# Altium schematic sheet ESP32.SchDoc(ESP32)
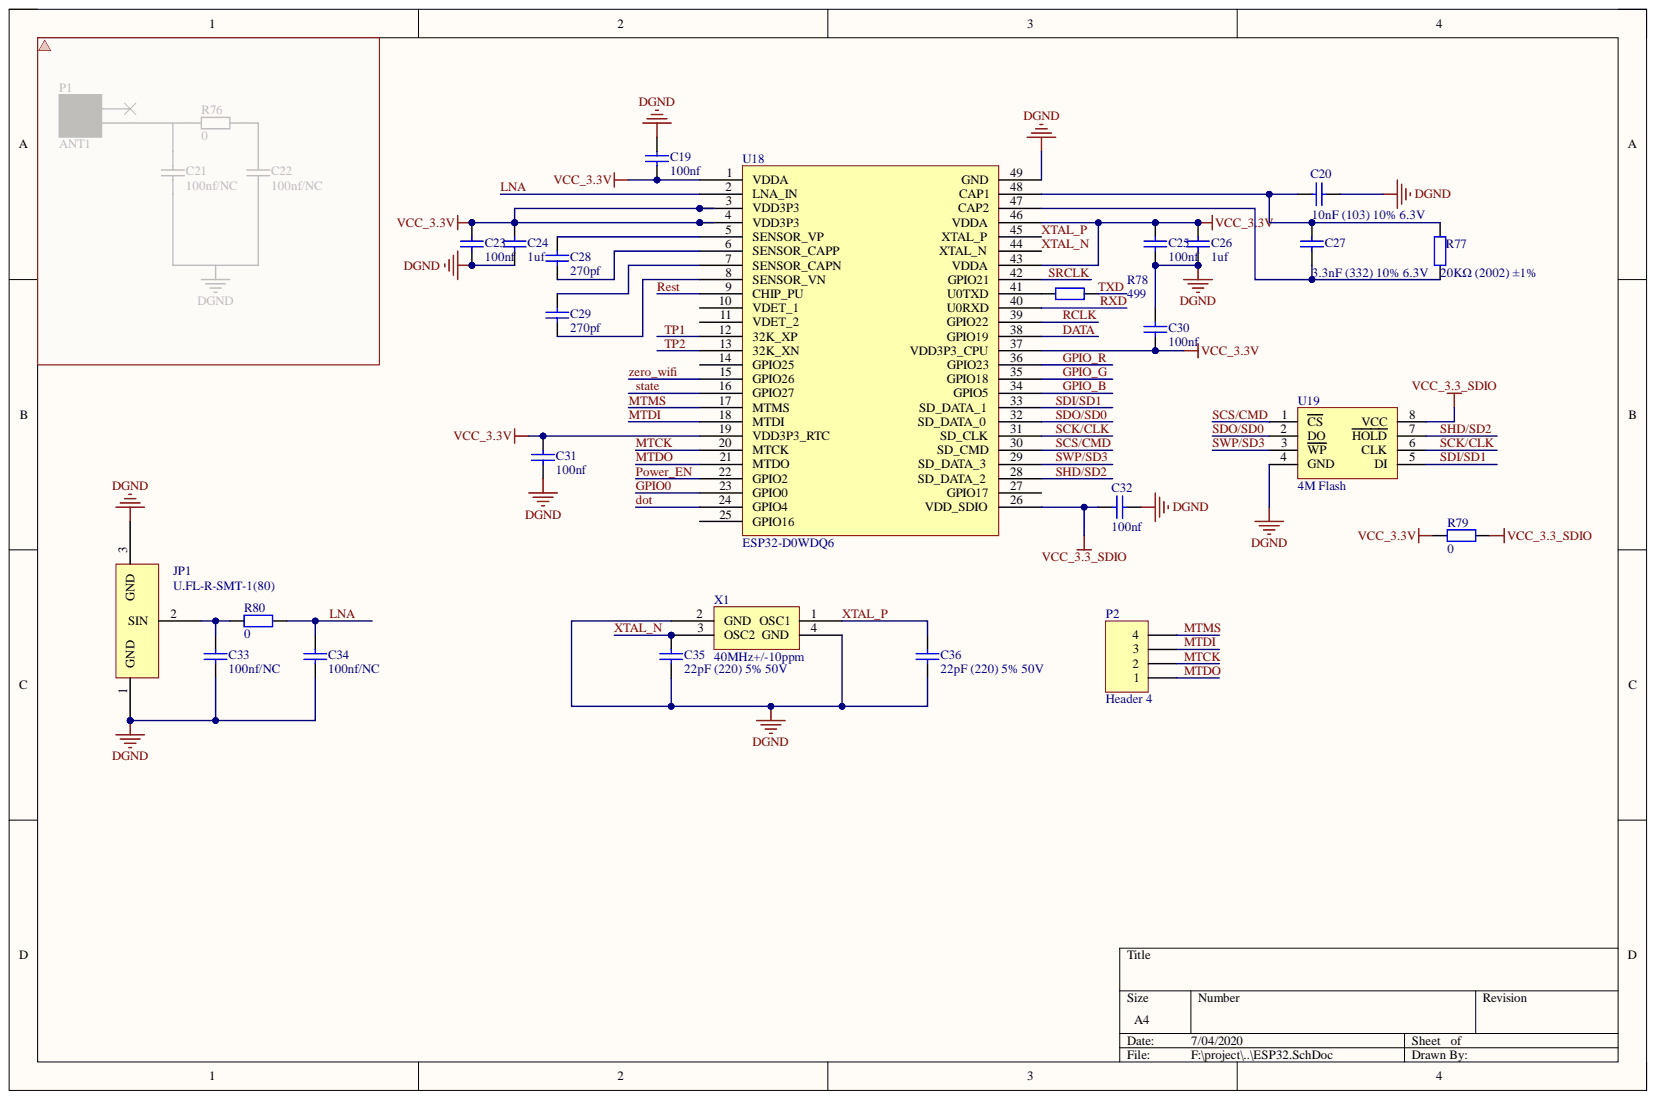
[diagram p0: ESP32.SchDoc]

## Parts
C19  100nf  [0603_C]
C20  10nF (103) 10% 6.3V  [0603_C]
C23  100nf  [0603_C]
C24  1uf  [0603_C]
C25  100nf  [0603_C]
C26  1uf  [0603_C]
C27  3.3nF (332) 10% 6.3V  [0603_C]
C28  270pf  [0603_C]
C29  270pf  [0603_C]
C30  100nf  [0603_C]
C31  100nf  [0603_C]
C32  100nf  [0603_C]
C33  100nf/NC  [0603_C]
C34  100nf/NC  [0603_C]
C35  22pF (220) 5% 50V  [0603_C]
C36  22pF (220) 5% 50V  [0603_C]
JP1  U.FL-R-SMT-1(80)  [RF-SMD_U.FL-R-SMT-1(80)]
P2  Header 4  [HDR1X4]
R77  20KΩ (2002) ±1%  [0603_R]
R78  499  [0603_R]
R79  0  [0603_R]
R80  0  [0603_R]
U18  ESP32-D0WDQ6  [QFN-48_L6.0-W6.0-P0.40-BL-EP3.8]
U19  4M Flash  [SOIC-8_208mil]
X1  40MHz+/-10ppm  [SMD-3225_4P]

## Nets
DGND: C19.2 C20.2 C23.2 C24.2 C25.2 C26.2 C30.1 C31.2 C32.1 C33.2 C34.2 C35.1 C36.1 JP1.1 JP1.3 U18.49(GND) U19.4(GND) X1.2(GND) X1.4(GND)
VCC_3.3_SDIO: C32.2 R79.1 U18.26(VDD_SDIO) U19.8(VCC)
VCC_3.3V: C19.1 C23.1 C24.1 C25.1 C26.1 C30.2 C31.1 R79.2 U18.1(VDDA) U18.3(VDD3P3) U18.4(VDD3P3) U18.19(VDD3P3_RTC) U18.37(VDD3P3_CPU) U18.43(VDDA) U18.46(VDDA)
DATA: U18.38(GPIO19)
dot: U18.24(GPIO4)
GPIO0: U18.23(GPIO0)
GPIO_B: U18.34(GPIO5)
GPIO_G: U18.35(GPIO18)
GPIO_R: U18.36(GPIO23)
LNA: C34.1 R80.2 U18.2(LNA_IN)
MTCK: P2.2 U18.20(MTCK)
MTDI: P2.3 U18.18(MTDI)
MTDO: P2.1 U18.21(MTDO)
MTMS: P2.4 U18.17(MTMS)
Power_EN: U18.22(GPIO2)
RCLK: U18.39(GPIO22)
Rest: U18.9(CHIP_PU)
RXD: U18.40(U0RXD)
SCK/CLK: U18.31(SD_CLK) U19.6(CLK)
SCS/CMD: U18.30(SD_CMD) U19.1(CS)
SDI/SD1: U18.33(SD_DATA_1) U19.5(DI)
SDO/SD0: U18.32(SD_DATA_0) U19.2(DO)
SHD/SD2: U18.28(SD_DATA_2) U19.7(HOLD)
SRCLK: U18.42(GPIO21)
state: U18.16(GPIO27)
SWP/SD3: U18.29(SD_DATA_3) U19.3(WP)
TP1: U18.12(32K_XP)
TP2: U18.13(32K_XN)
TXD: R78.2
XTAL_N: C35.2 U18.44(XTAL_N) X1.3(OSC2)
XTAL_P: C36.2 U18.45(XTAL_P) X1.1(OSC1)
zero_wifi: U18.15(GPIO26)
NetC20_1: C20.1 C27.1 R77.1 U18.48(CAP1)
NetC27_2: C27.2 R77.2 U18.47(CAP2)
NetC28_1: C28.1 U18.5(SENSOR_VP)
NetC28_2: C28.2 U18.6(SENSOR_CAPP)
NetC29_1: C29.1 U18.7(SENSOR_CAPN)
NetC29_2: C29.2 U18.8(SENSOR_VN)
NetC33_1: C33.1 JP1.2 R80.1
NetR78_1: R78.1 U18.41(U0TXD)

Not connected: U18 10(VDET_1) 11(VDET_2) 14(GPIO25) 25(GPIO16) 27(GPIO17)

## Library data of the parts
C19 C23 C25 C28-C34 = 0603 100nF (104) 10% 50V: 贴片电容; Component Kind: Standard; ComponentLink1Description: 供应商链接; ComponentLink1URL: http://www.szlcsc.com/product/details_15331.html; Library Name: Miscellaneous Devices LC.IntLib; Package: 0603; Supplier: LC; SuppliersPartNumber: C14663
C20 = 0603 10nF (103) 10% 50V: 贴片电容; Component Kind: Standard; ComponentLink1Description: 供应商链接; ComponentLink1URL: http://www.szlcsc.com/product/details_58143.html; Library Name: Miscellaneous Devices LC.IntLib; Package: 0603; Supplier: LC; SuppliersPartNumber: C57112
C24 C26 = 0603 1uF (105) 10% 50V: 贴片电容; Component Kind: Standard; ComponentLink1Description: 供应商链接; ComponentLink1URL: http://www.szlcsc.com/product/details_16531.html; Library Name: Miscellaneous Devices LC.IntLib; Package: 0603; Supplier: LC; SuppliersPartNumber: C15849
C27 = 0603 3.3nF (332) 10% 50V: 贴片电容; Component Kind: Standard; Library Name: Miscellaneous Devices LC.IntLib; Package: 0603; Supplier: LC; SuppliersPartNumber: C1613
C35 C36 = 0603 22pF (220) 5% 50V: 贴片电容; Component Kind: Standard; ComponentLink1Description: 供应商链接; ComponentLink1URL: http://www.szlcsc.com/product/details_2005.html; Library Name: Miscellaneous Devices LC.IntLib; Package: 0603; Supplier: LC; SuppliersPartNumber: C1653
JP1 = IPE-X: Component Kind: Standard; Library Name: IV-3a.IntLib
P2 = Header 4: Header, 4-Pin; Component Kind: Standard; LatestRevisionDate: 17-Jul-2002; LatestRevisionNote: Re-released for DXP Platform.; Library Name: Miscellaneous Connectors.IntLib; Signal Integrity: Connector; Publisher: Altium Limited
R77 = 0603 20KΩ (2002) 1%: 贴片电阻; Component Kind: Standard; ComponentLink1Description: 供应商链接; ComponentLink1URL: http://www.szlcsc.com/product/details_4591.html; Library Name: Miscellaneous Devices LC.IntLib; Package: 0603; Supplier: LC; SuppliersPartNumber: C4184
R78-R80 = 0603 180Ω (1800) 1%: 贴片电阻; Component Kind: Standard; Library Name: Miscellaneous Devices LC.IntLib; Package: 0603; Supplier: LC; SuppliersPartNumber: C22828
U19 = W25Q128JVSIQTR_[C97521]: Flash ROM; Component Kind: Standard; ComponentLink1Description: 供应商链接; ComponentLink1URL: https://item.szlcsc.com/98729.html; Library Name: Miscellaneous Devices LC.IntLib; Package: SOIC-8; Supplier: LC; SuppliersPartNumber: C97521
X1 = 40MHz+/-10ppm: SX32Y040000BA1T; Component Kind: Standard; Library Name: IV-3a.IntLib
U18 = ESP32-D0WDQ6: Component Kind: Standard; Library Name: IV-3a.IntLib
